# Altium schematic sheet MCU.SchDoc
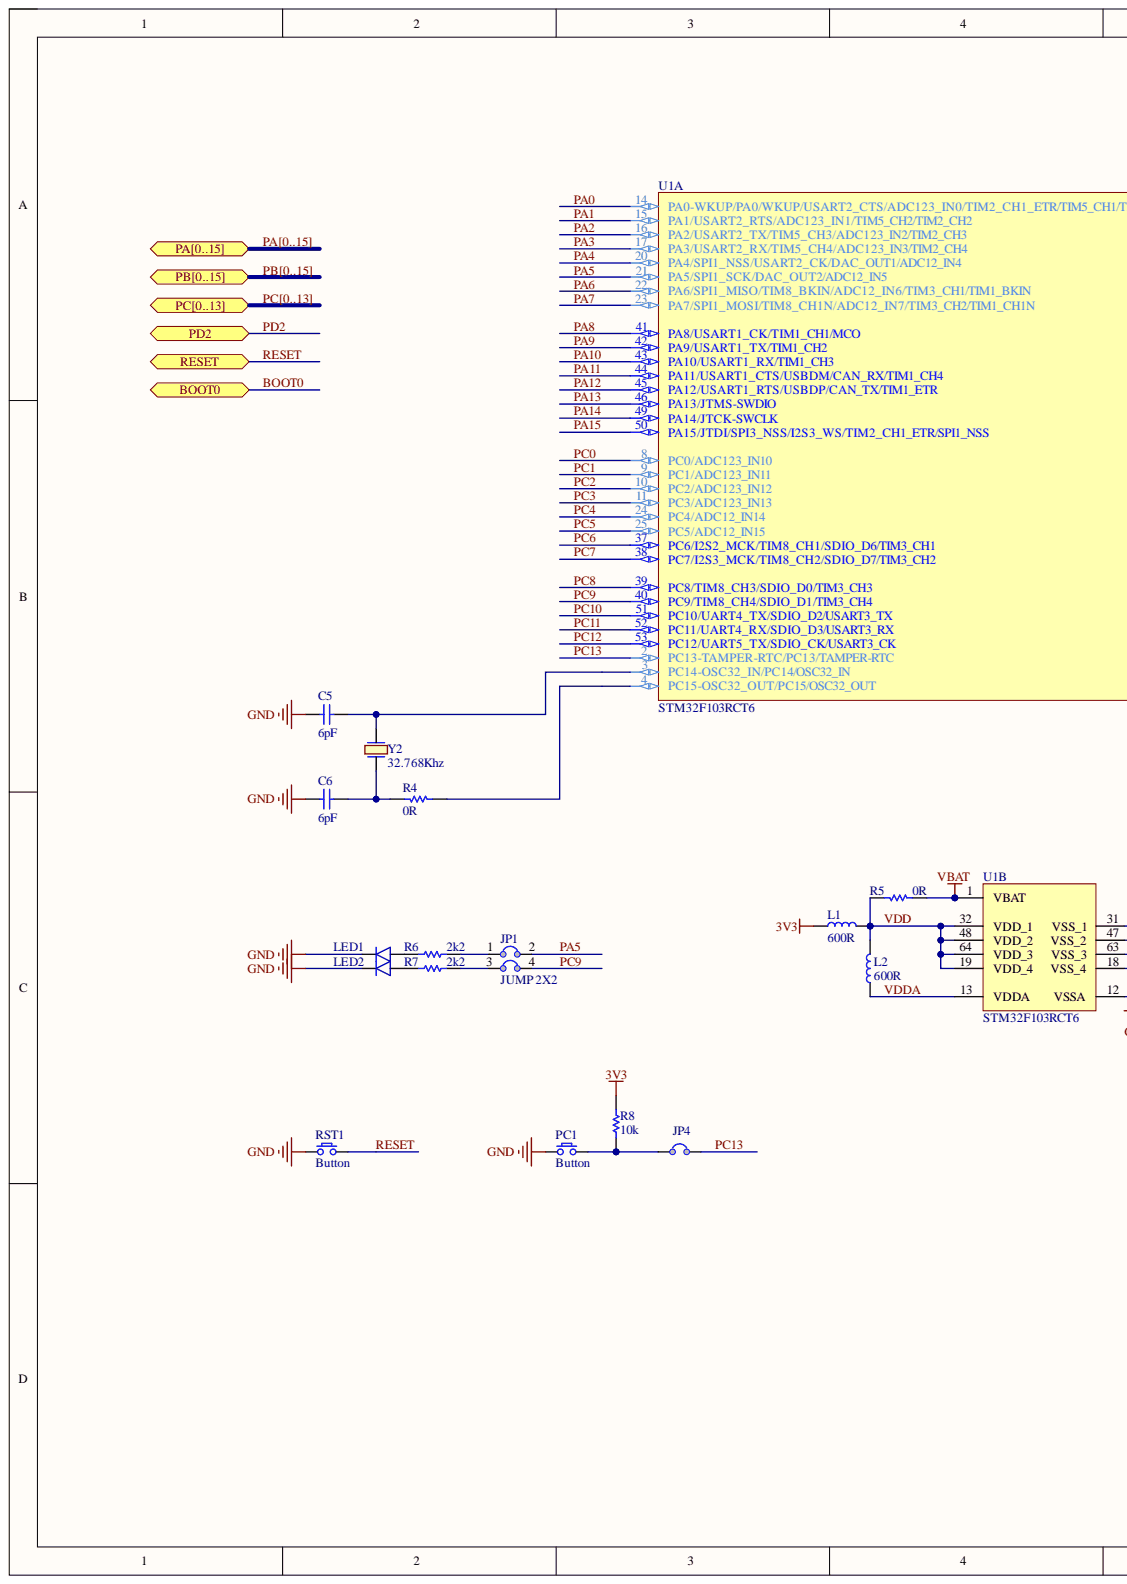
[diagram p0: MCU.SchDoc, left]
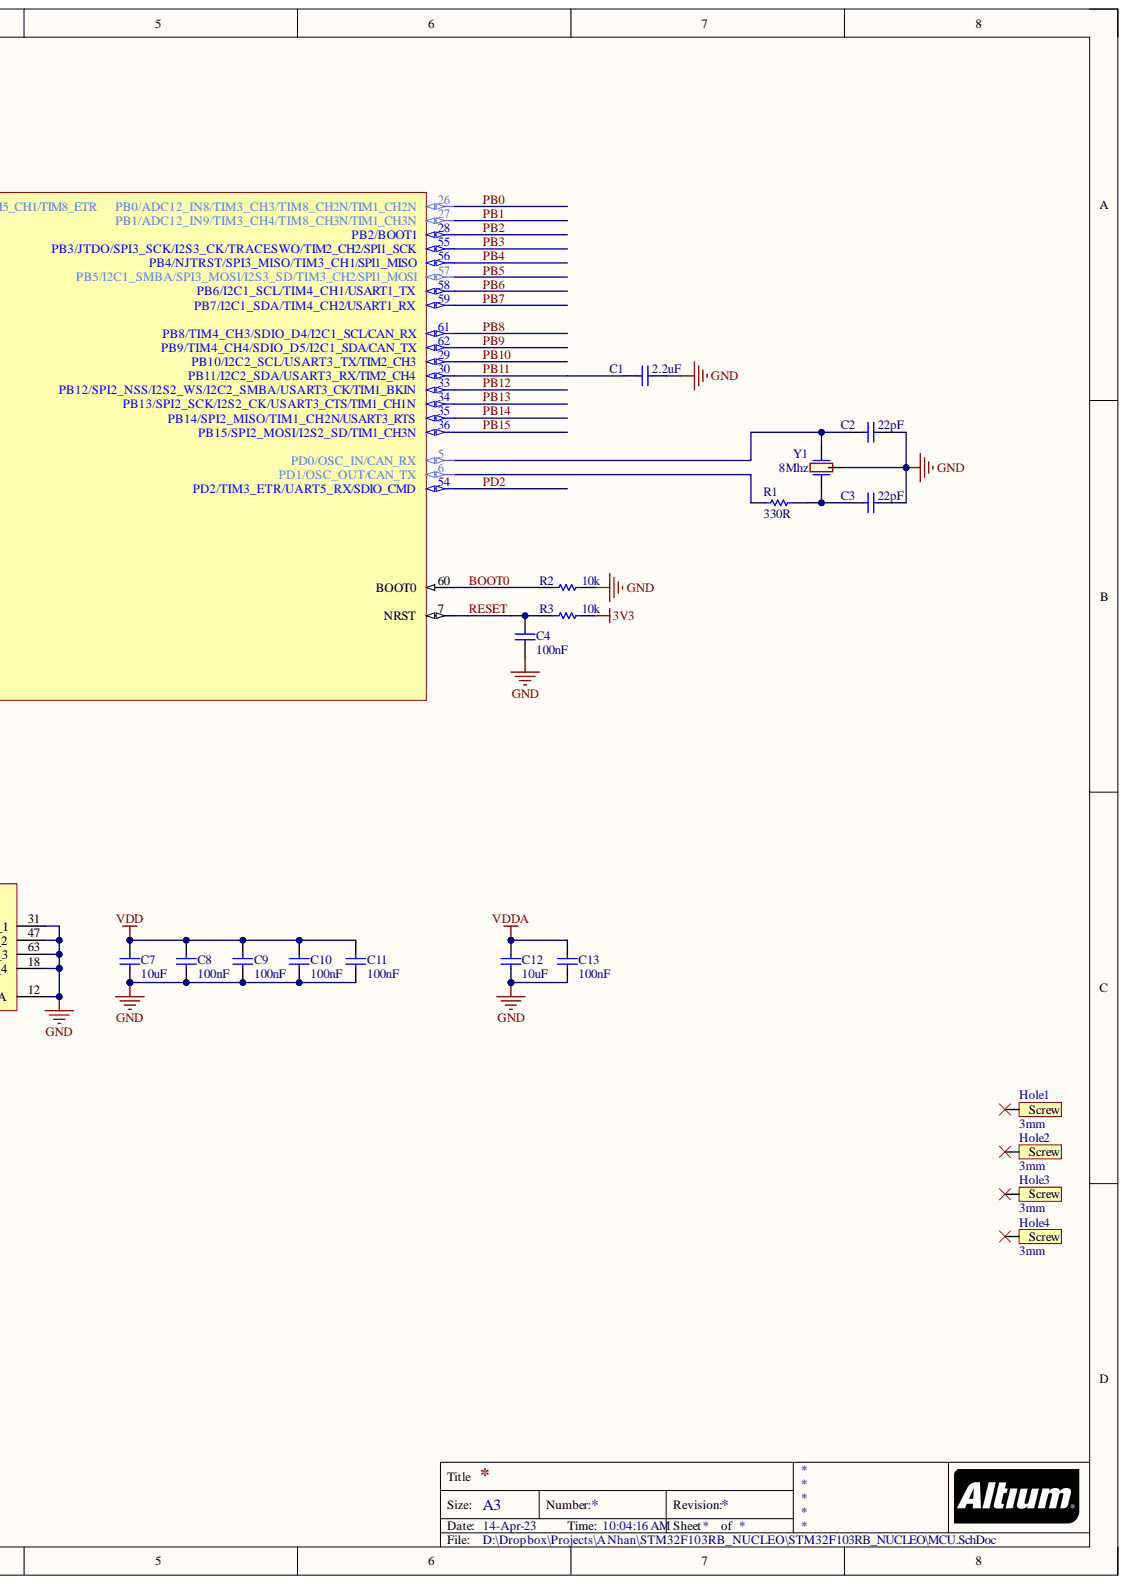
[diagram p1: MCU.SchDoc, right]

## Parts
C1  2.2uF  [C 0603 (1608)]
C2  22pF  [C 0603 (1608)]
C3  22pF  [C 0603 (1608)]
C4  100nF  [C 0603 (1608)]
C5  6pF  [C 0603 (1608)]
C6  6pF  [C 0603 (1608)]
C7  10uF  [C 0603 (1608)]
C8  100nF  [C 0603 (1608)]
C9  100nF  [C 0603 (1608)]
C10  100nF  [C 0603 (1608)]
C11  100nF  [C 0603 (1608)]
C12  10uF  [C 0603 (1608)]
C13  100nF  [C 0603 (1608)]
Hole1  3mm  [SCREW/3M/7M]
Hole2  3mm  [SCREW/3M/7M]
Hole3  3mm  [SCREW/3M/7M]
Hole4  3mm  [SCREW/3M/7M]
JP1  JUMP 2X2  [JUMPER 2x2]
JP4  JUMP 2  [JUMPER 2]  (value not drawn)
L1  600R  [Inductor SMD 0603 (1608)]
L2  600R  [Inductor SMD 0603 (1608)]
LED1  BlueLed  [Led SMD 0603]  (value not drawn)
LED2  BlueLed  [Led SMD 0603]  (value not drawn)
PC1  Button  [SW/2/SMD]
R1  330R  [R 0603 (1608)]
R2  10k  [R 0603 (1608)]
R3  10k  [R 0603 (1608)]
R4  0R  [R 0603 (1608)]
R5  0R  [R 0603 (1608)]
R6  2k2  [R 0603 (1608)]
R7  2k2  [R 0603 (1608)]
R8  10k  [R 0603 (1608)]
RST1  Button  [SW/2/SMD]
U1  STM32F103RCT6  [LQFP64_N]  (parts A,B)
Y1  8Mhz  [Crystal SMD CSTCE]
Y2  32.768Khz  [Crystal SMD FC135]

## Ports
BOOT0, PA0, PA1, PA2, PA3, PA4, PA5, PA6, PA7, PA8, PA9, PA10, PA11, PA12, PA13, PA14, PA15, PA[0..15], PB0, PB1, PB2, PB3, PB4, PB5, PB6, PB7, PB8, PB9, PB10, PB11, PB12, PB13, PB14, PB15, PB[0..15], PC0, PC1, PC2, PC3, PC4, PC5, PC6, PC7, PC8, PC9, PC10, PC11, PC12, PC13, PC[0..13], PD2, RESET

## Nets
3V3: L1.1 R3.1 R8.2
GND: C1.1 C2.2 C3.2 C4.1 C5.2 C6.2 C7.1 C8.1 C9.1 C10.1 C11.1 C12.1 C13.1 LED1.2 LED2.2 PC1.1 R2.1 RST1.1 U1.12(VSSA) U1.18(VSS_4) U1.31(VSS_1) U1.47(VSS_2) U1.63(VSS_3) Y1.2
VBAT: R5.2 U1.1(VBAT)
BOOT0: R2.2 U1.60(BOOT0) ; port BOOT0
PA0: U1.14(PA0-WKUP/PA0/WKUP/USART2_CTS/ADC123_IN0/TIM2_CH1_ETR/TIM5_CH1/TIM8_ETR) ; port PA[0..15]  [also labelled PA]
PA1: U1.15(PA1/USART2_RTS/ADC123_IN1/TIM5_CH2/TIM2_CH2) ; port PA[0..15]  [also labelled PA]
PA2: U1.16(PA2/USART2_TX/TIM5_CH3/ADC123_IN2/TIM2_CH3) ; port PA[0..15]  [also labelled PA]
PA3: U1.17(PA3/USART2_RX/TIM5_CH4/ADC123_IN3/TIM2_CH4) ; port PA[0..15]  [also labelled PA]
PA4: U1.20(PA4/SPI1_NSS/USART2_CK/DAC_OUT1/ADC12_IN4) ; port PA[0..15]  [also labelled PA]
PA5: JP1.2 U1.21(PA5/SPI1_SCK/DAC_OUT2/ADC12_IN5) ; port PA[0..15]  [also labelled PA]
PA6: U1.22(PA6/SPI1_MISO/TIM8_BKIN/ADC12_IN6/TIM3_CH1/TIM1_BKIN) ; port PA[0..15]  [also labelled PA]
PA7: U1.23(PA7/SPI1_MOSI/TIM8_CH1N/ADC12_IN7/TIM3_CH2/TIM1_CH1N) ; port PA[0..15]  [also labelled PA]
PA8: U1.41(PA8/USART1_CK/TIM1_CH1/MCO) ; port PA[0..15]  [also labelled PA]
PA9: U1.42(PA9/USART1_TX/TIM1_CH2) ; port PA[0..15]  [also labelled PA]
PA10: U1.43(PA10/USART1_RX/TIM1_CH3) ; port PA[0..15]  [also labelled PA]
PA11: U1.44(PA11/USART1_CTS/USBDM/CAN_RX/TIM1_CH4) ; port PA[0..15]  [also labelled PA]
PA12: U1.45(PA12/USART1_RTS/USBDP/CAN_TX/TIM1_ETR) ; port PA[0..15]  [also labelled PA]
PA13: U1.46(PA13/JTMS-SWDIO) ; port PA[0..15]  [also labelled PA]
PA14: U1.49(PA14/JTCK-SWCLK) ; port PA[0..15]  [also labelled PA]
PA15: U1.50(PA15/JTDI/SPI3_NSS/I2S3_WS/TIM2_CH1_ETR/SPI1_NSS) ; port PA[0..15]  [also labelled PA]
PB0: U1.26(PB0/ADC12_IN8/TIM3_CH3/TIM8_CH2N/TIM1_CH2N) ; port PB[0..15]  [also labelled PB]
PB1: U1.27(PB1/ADC12_IN9/TIM3_CH4/TIM8_CH3N/TIM1_CH3N) ; port PB[0..15]  [also labelled PB]
PB2: U1.28(PB2/BOOT1) ; port PB[0..15]  [also labelled PB]
PB3: U1.55(PB3/JTDO/SPI3_SCK/I2S3_CK/TRACESWO/TIM2_CH2/SPI1_SCK) ; port PB[0..15]  [also labelled PB]
PB4: U1.56(PB4/NJTRST/SPI3_MISO/TIM3_CH1/SPI1_MISO) ; port PB[0..15]  [also labelled PB]
PB5: U1.57(PB5/I2C1_SMBA/SPI3_MOSI/I2S3_SD/TIM3_CH2/SPI1_MOSI) ; port PB[0..15]  [also labelled PB]
PB6: U1.58(PB6/I2C1_SCL/TIM4_CH1/USART1_TX) ; port PB[0..15]  [also labelled PB]
PB7: U1.59(PB7/I2C1_SDA/TIM4_CH2/USART1_RX) ; port PB[0..15]  [also labelled PB]
PB8: U1.61(PB8/TIM4_CH3/SDIO_D4/I2C1_SCL/CAN_RX) ; port PB[0..15]  [also labelled PB]
PB9: U1.62(PB9/TIM4_CH4/SDIO_D5/I2C1_SDA/CAN_TX) ; port PB[0..15]  [also labelled PB]
PB10: U1.29(PB10/I2C2_SCL/USART3_TX/TIM2_CH3) ; port PB[0..15]  [also labelled PB]
PB11: C1.2 U1.30(PB11/I2C2_SDA/USART3_RX/TIM2_CH4) ; port PB[0..15]  [also labelled PB]
PB12: U1.33(PB12/SPI2_NSS/I2S2_WS/I2C2_SMBA/USART3_CK/TIM1_BKIN) ; port PB[0..15]  [also labelled PB]
PB13: U1.34(PB13/SPI2_SCK/I2S2_CK/USART3_CTS/TIM1_CH1N) ; port PB[0..15]  [also labelled PB]
PB14: U1.35(PB14/SPI2_MISO/TIM1_CH2N/USART3_RTS) ; port PB[0..15]  [also labelled PB]
PB15: U1.36(PB15/SPI2_MOSI/I2S2_SD/TIM1_CH3N) ; port PB[0..15]  [also labelled PB]
PC0: U1.8(PC0/ADC123_IN10) ; port PC[0..13]  [also labelled PC]
PC1: U1.9(PC1/ADC123_IN11) ; port PC[0..13]  [also labelled PC]
PC2: U1.10(PC2/ADC123_IN12) ; port PC[0..13]  [also labelled PC]
PC3: U1.11(PC3/ADC123_IN13) ; port PC[0..13]  [also labelled PC]
PC4: U1.24(PC4/ADC12_IN14) ; port PC[0..13]  [also labelled PC]
PC5: U1.25(PC5/ADC12_IN15) ; port PC[0..13]  [also labelled PC]
PC6: U1.37(PC6/I2S2_MCK/TIM8_CH1/SDIO_D6/TIM3_CH1) ; port PC[0..13]  [also labelled PC]
PC7: U1.38(PC7/I2S3_MCK/TIM8_CH2/SDIO_D7/TIM3_CH2) ; port PC[0..13]  [also labelled PC]
PC8: U1.39(PC8/TIM8_CH3/SDIO_D0/TIM3_CH3) ; port PC[0..13]  [also labelled PC]
PC9: JP1.4 U1.40(PC9/TIM8_CH4/SDIO_D1/TIM3_CH4) ; port PC[0..13]  [also labelled PC]
PC10: U1.51(PC10/UART4_TX/SDIO_D2/USART3_TX) ; port PC[0..13]  [also labelled PC]
PC11: U1.52(PC11/UART4_RX/SDIO_D3/USART3_RX) ; port PC[0..13]  [also labelled PC]
PC12: U1.53(PC12/UART5_TX/SDIO_CK/USART3_CK) ; port PC[0..13]  [also labelled PC]
PC13: JP4.2 U1.2(PC13-TAMPER-RTC/PC13/TAMPER-RTC) ; port PC[0..13]  [also labelled PC]
PD2: U1.54(PD2/TIM3_ETR/UART5_RX/SDIO_CMD) ; port PD2
RESET: C4.2 R3.2 RST1.2 U1.7(NRST) ; port RESET
VDD: C7.2 C8.2 C9.2 C10.2 C11.2 L1.2 L2.2 R5.1 U1.19(VDD_4) U1.32(VDD_1) U1.48(VDD_2) U1.64(VDD_3)
VDDA: C12.2 C13.2 L2.1 U1.13(VDDA)
NetC2_1: C2.1 U1.5(PD0/OSC_IN/CAN_RX) Y1.3
NetC3_1: C3.1 R1.1 Y1.1
NetC5_1: C5.1 U1.3(PC14-OSC32_IN/PC14/OSC32_IN) Y2.1
NetC6_1: C6.1 R4.1 Y2.2
NetJP1_1: JP1.1 R6.2
NetJP1_3: JP1.3 R7.2
NetJP4_1: JP4.1 PC1.2 R8.1
NetLED1_1: LED1.1 R6.1
NetLED2_1: LED2.1 R7.1
NetR1_2: R1.2 U1.6(PD1/OSC_OUT/CAN_TX)
NetR4_2: R4.2 U1.4(PC15-OSC32_OUT/PC15/OSC32_OUT)

Not connected: Hole1 1; Hole2 1; Hole3 1; Hole4 1

## Library data of the parts
C1-C13 = Capacitor NP: Capacitor non-pol; Component Kind: Standard; Library Name: BKIT4U_LIB.SchLib
Hole1-Hole4 = Screw: Component Kind: Standard; Library Name: BKIT4U_LIB.SchLib
L1 L2 = Inductor: Component Kind: Standard; Description: Inductor; Library Name: BKIT4U_LIB.SchLib
LED1 LED2 = Led: Typical LED; Component Kind: Standard; Library Name: BKIT4U_LIB.SchLib
PC1 RST1 = SW SPST: Tact switch 2 pin; Component Kind: Standard; Library Name: BKIT4U_LIB.SchLib
R1-R8 = Resistor: Component Kind: Standard; Description: Resistor; LatestRevisionDate: 17-Jul-2002; LatestRevisionNote: Re-released for DXP Platform.; Library Name: BKIT4U_LIB.SchLib; PackageReference: AXIAL-0.3; Published: 8-Jun-2000; Publisher: Altium Limited
U1 = STM32F103RCT6: ARM Cortex-M3 32-bit MCU, 256 KB Flash, 48 KB Internal RAM, 51 I/Os, 64-pin LQFP, -40 to 85 degC, Tray; A/D Converter: 3x12-bit (16 Channel); D/A Converter: 2x12-bit (2 Channel); FLASH Size (Prog) (kB): 256; I/Os (High Current): 51; Internal RAM Size (kB): 48; Operating Frequency(F) (Processor speed) (MHz): 72; Supply Voltage(Vcc) min (V): 2; Component Kind: Standard; ComponentLink1Description: Manufacturer URL; ComponentLink1URL: http://www.st.com/web/en/home.html; ComponentLink2Description: Datasheet; ComponentLink2URL: http://www.st.com/st-web-ui/static/active/en/resource/technical/document/datasheet/CD00191185.pdf; ComponentLink3Description: Package Specification; ComponentLink3URL: http://www.st.com/st-web-ui/static/active/en/resource/technical/document/datasheet/DM00037051.pdf; Core: ARM Cortex-M3; DatasheetVersion: Rev. 8; Library Name: BKIT4U_LIB.SchLib; Mounting Technology: Surface Mount; PackageDescription: 64-Pin Low Profile Quad Flat Package, 10 x 10 mm Body, 0.5 mm Pitch; PackageReference: LQFP64; PackageVersion: Rev. 4, 06/2013; Packing: Tray; PartNumber: STM32F103RCT6; Supplier 1: Digi-Key; Supplier 2: Newark; Supplier 3: Newark; Supplier 4: Mouser; Supplier Part Number 1: 497-9033-ND; Supplier Part Number 2: 01P4099; Supplier Part Number 3: 57P1227; Supplier Part Number 4: 511-STM32F103RCT6
Y1 = Crystal CSTCE: Component Kind: Standard; Library Name: BKIT4U_LIB.SchLib
Y2 = Crystal: Component Kind: Standard; Library Name: BKIT4U_LIB.SchLib
JP1 = JUMP 2X2: Component Kind: Standard; LatestRevisionDate: 17-Jul-2002; LatestRevisionNote: Re-released for DXP Platform.; Library Name: BKIT4U_LIB.SchLib; Publisher: Altium Limited
JP4 = JUMP 2: Component Kind: Standard; Library Name: BKIT4U_LIB.SchLib
